# Altium schematic sheet Nav_Ducer_ADC.SchDoc
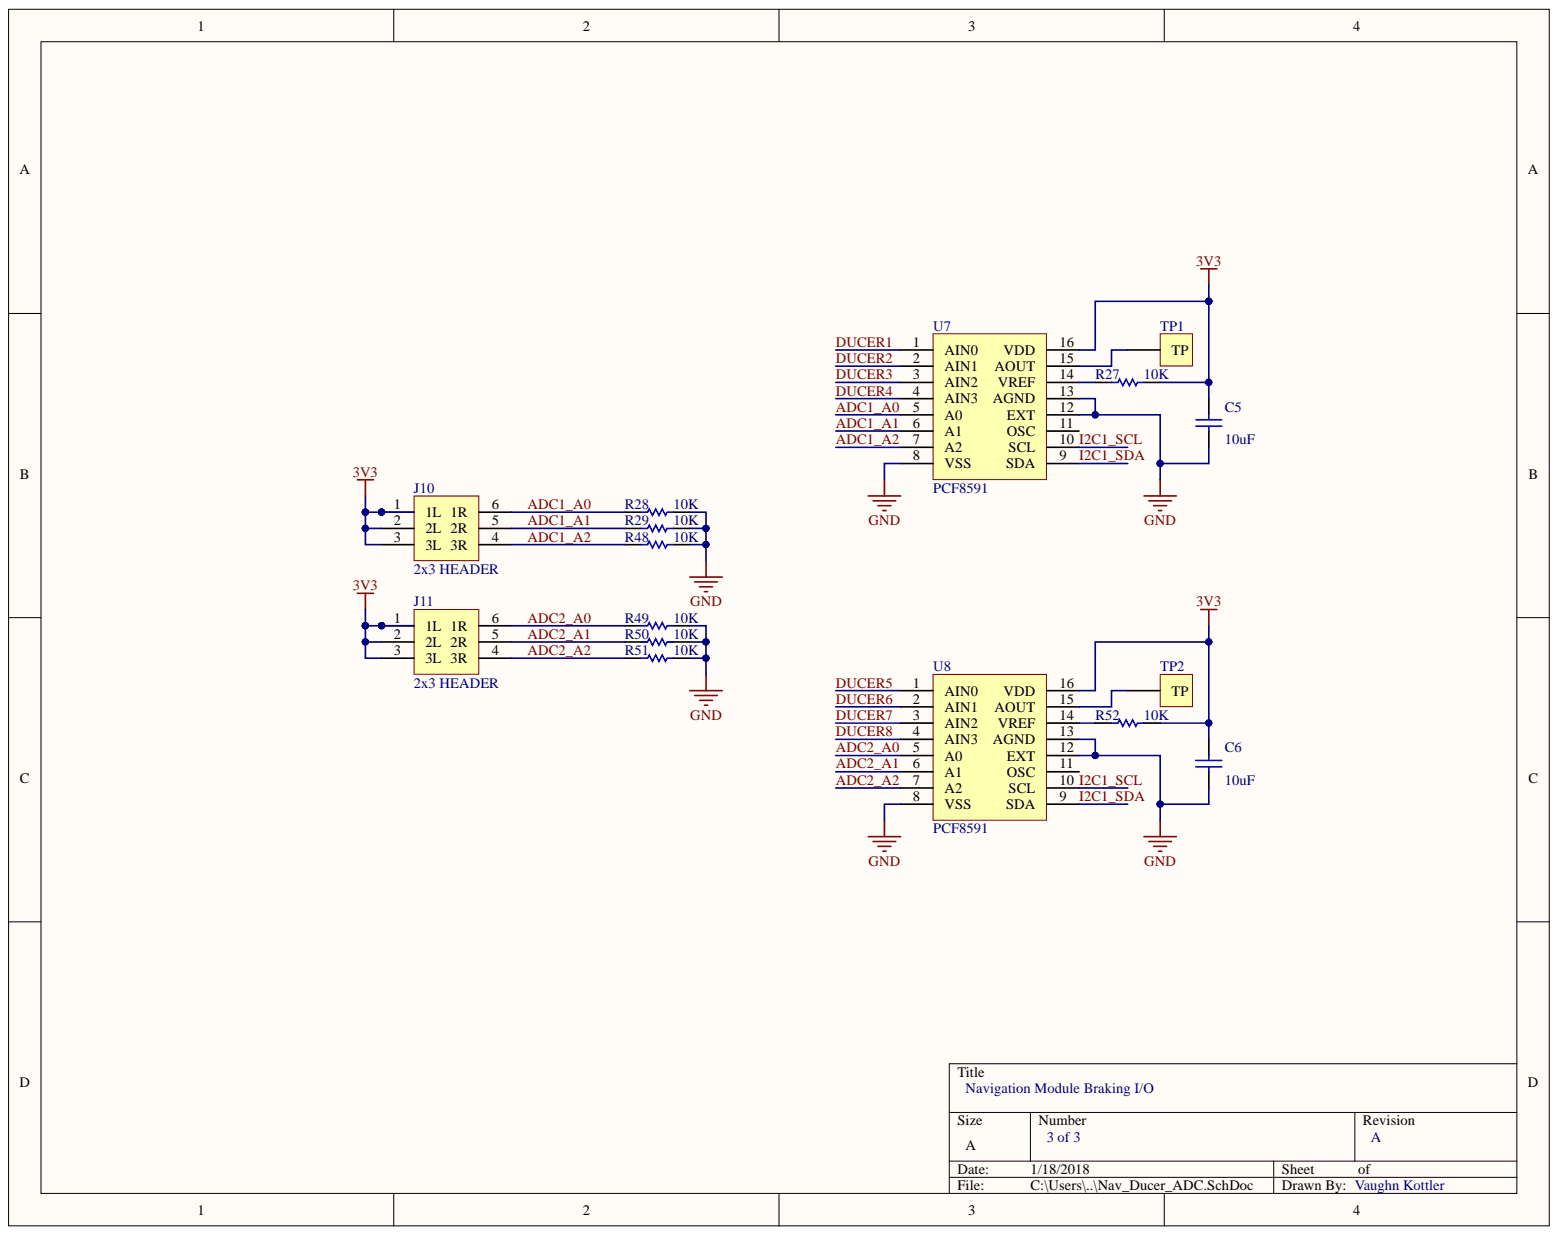
[diagram p0: Nav_Ducer_ADC.SchDoc]

## Parts
C5  value 10uF  [C1206]  (hidden comment: Cap)
C6  value 10uF  [C1206]  (hidden comment: Cap)
J10  2x3 HEADER  [DIP6]
J11  2x3 HEADER  [DIP6]
R27  value 10K  [6-0805_M]  (hidden comment: Res1)
R28  value 10K  [6-0805_M]  (hidden comment: Res1)
R29  value 10K  [6-0805_M]  (hidden comment: Res1)
R48  value 10K  [6-0805_M]  (hidden comment: Res1)
R49  value 10K  [6-0805_M]  (hidden comment: Res1)
R50  value 10K  [6-0805_M]  (hidden comment: Res1)
R51  value 10K  [6-0805_M]  (hidden comment: Res1)
R52  value 10K  [6-0805_M]  (hidden comment: Res1)
TP1  Test Point  [TESTPOINT]  (value not drawn)
TP2  Test Point  [TESTPOINT]  (value not drawn)
U7  PCF8591  [16-SOIC]
U8  PCF8591  [16-SOIC]

## Nets
3V3: C5.2 C6.2 J10.1(1L) J10.2(2L) J10.3(3L) J11.1(1L) J11.2(2L) J11.3(3L) R27.1 R52.1 U7.16(VDD) U8.16(VDD)
GND: C5.1 C6.1 R28.1 R29.1 R48.1 R49.1 R50.1 R51.1 U7.8(VSS) U7.12(EXT) U7.13(AGND) U8.8(VSS) U8.12(EXT) U8.13(AGND)
ADC1_A0: J10.6(1R) R28.2 U7.5(A0)
ADC1_A1: J10.5(2R) R29.2 U7.6(A1)
ADC1_A2: J10.4(3R) R48.2 U7.7(A2)
ADC2_A0: J11.6(1R) R49.2 U8.5(A0)
ADC2_A1: J11.5(2R) R50.2 U8.6(A1)
ADC2_A2: J11.4(3R) R51.2 U8.7(A2)
DUCER1: U7.1(AIN0)
DUCER2: U7.2(AIN1)
DUCER3: U7.3(AIN2)
DUCER4: U7.4(AIN3)
DUCER5: U8.1(AIN0)
DUCER6: U8.2(AIN1)
DUCER7: U8.3(AIN2)
DUCER8: U8.4(AIN3)
I2C1_SCL: U7.10(SCL) U8.10(SCL)
I2C1_SDA: U7.9(SDA) U8.9(SDA)
NetR27_2: R27.2 U7.14(VREF)
NetR52_2: R52.2 U8.14(VREF)
NetTP1_1: TP1.1 U7.15(AOUT)
NetTP2_1: TP2.1 U8.15(AOUT)

Not connected: U7 11(OSC); U8 11(OSC)

## Library data of the parts
C5 C6 = Cap: Capacitor; Component Kind: Standard; LatestRevisionDate: 17-Jul-2002; LatestRevisionNote: Re-released for DXP Platform.; Library Name: Miscellaneous Devices.IntLib; PackageDescription: Radial Cap, Thru-Hole; 2 Leads; 0.3 in Pin Spacing; PackageReference: RAD-0.3; Published: 8-Jun-2000; Signal Integrity: Cap; Simulation: CAP; Publisher: Altium Limited
R27-R29 R48-R52 = Res1: Resistor; Component Kind: Standard; LatestRevisionDate: 17-Jul-2002; LatestRevisionNote: Re-released for DXP Platform.; Library Name: Miscellaneous Devices.IntLib; PackageDescription: Axial Device, Thru-Hole; 2 Leads; 0.3 in Pin Spacing; PackageReference: AXIAL-0.3; Published: 8-Jun-2000; Signal Integrity: Res; Simulation: RESISTOR; Publisher: Altium Limited
U7 U8 = PCF8591: 8-bit A/D and D/A; Component Kind: Standard; ComponentLink1Description: Part; ComponentLink1URL: https://www.digikey.com/product-detail/en/nxp-usa-inc/PCF8591T-2518/568-1088-1-ND/735798; ComponentLink2Description: Datasheet; ComponentLink2URL: https://www.nxp.com/docs/en/data-sheet/PCF8591.pdf; Library Name: ic.SchLib
J10 J11 = 2x3 HEADER: Component Kind: Standard; Library Name: connector.SchLib
TP1 TP2 = Test Point: Component Kind: Standard; Library Name: connector.SchLib
